# Altium schematic sheet LM399.SchDoc
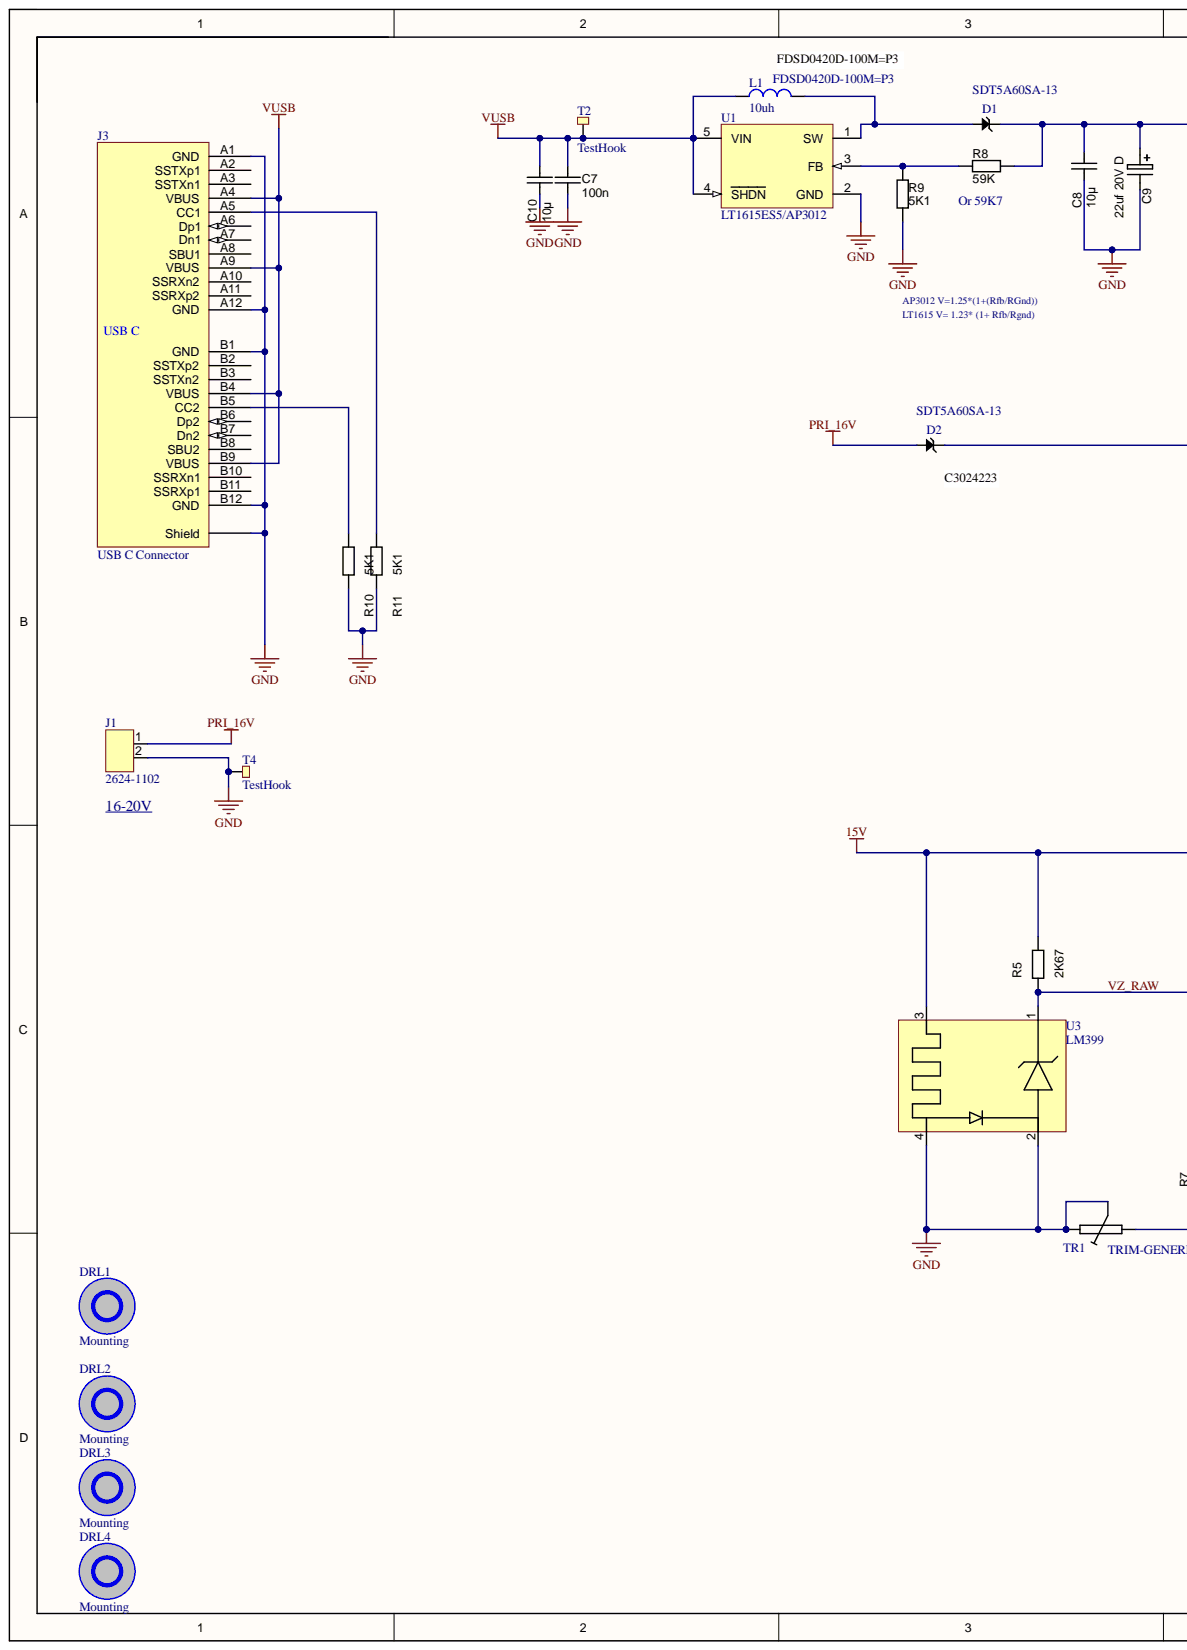
[diagram p0: LM399.SchDoc, left]
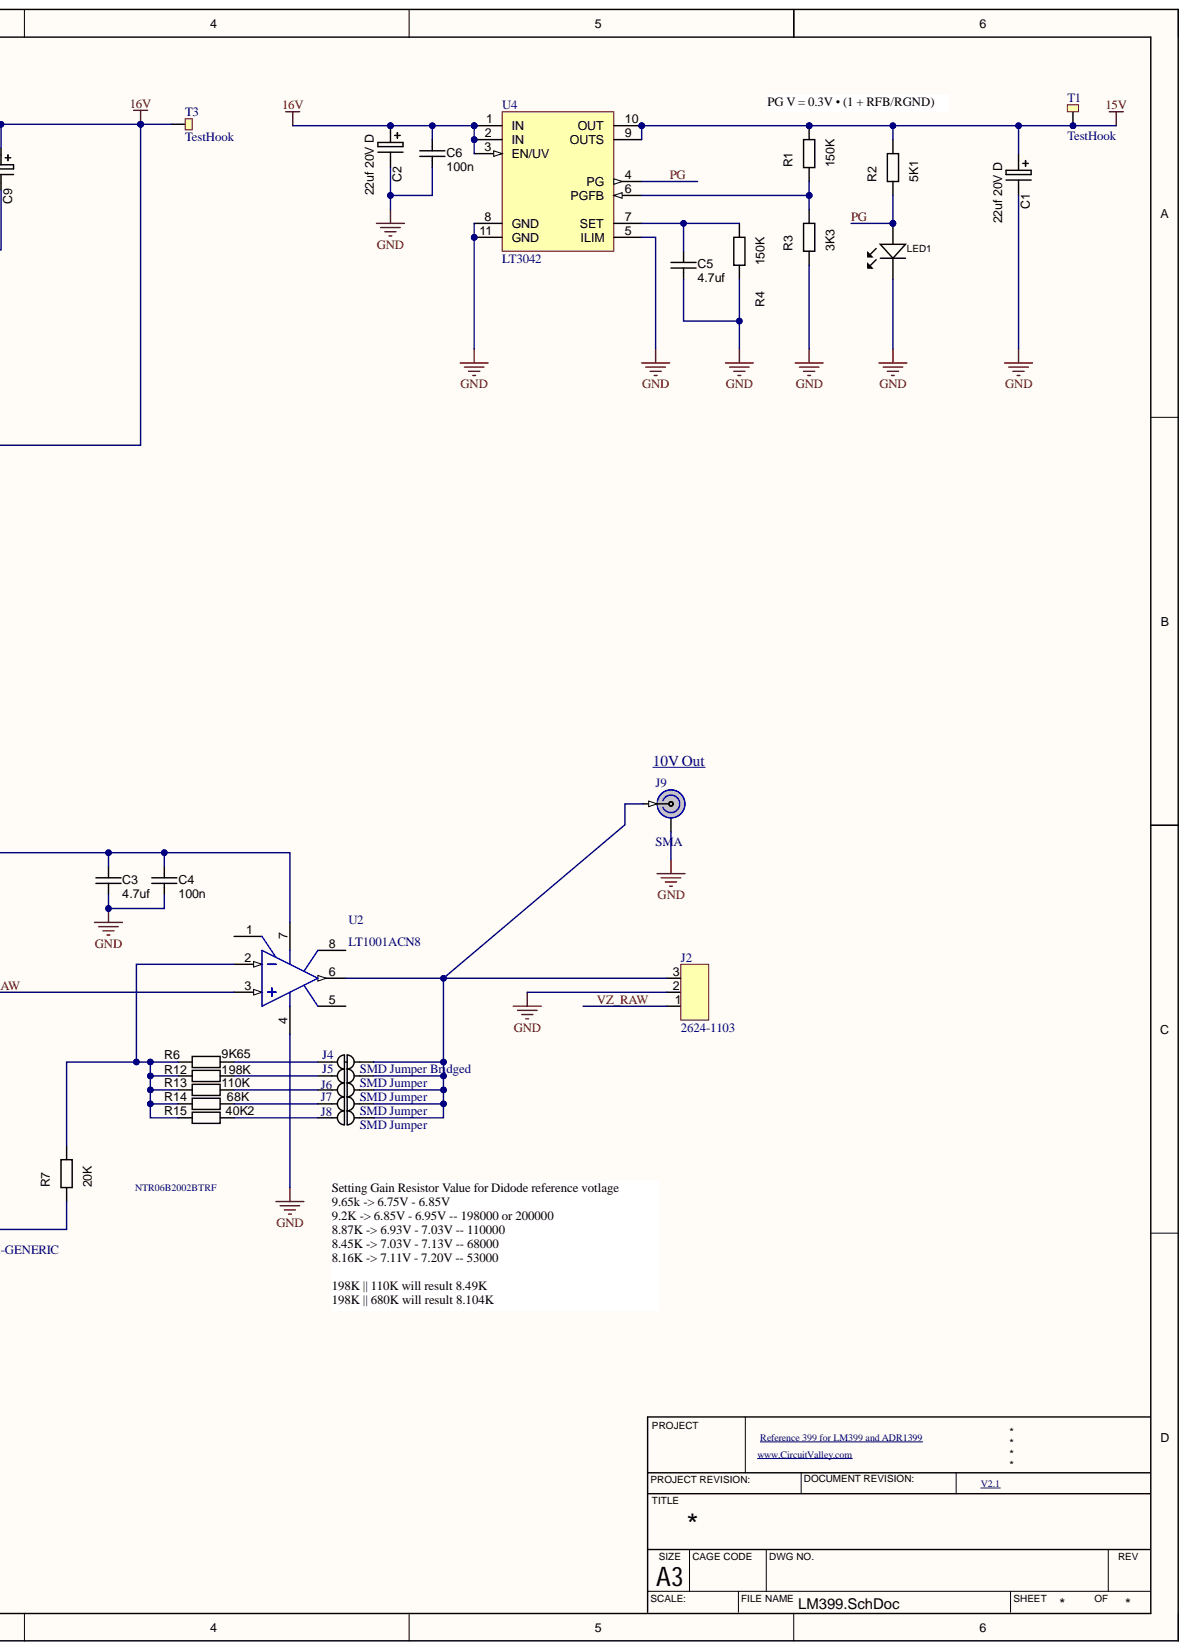
[diagram p1: LM399.SchDoc, right]

## Parts
C1  value 22uf 20V D  Manufacturer Size Code: D  [CAPMP7343X310N]  (hidden comment: 47uf 20V D)
C2  value 22uf 20V D  Manufacturer Size Code: D  [CAPMP7343X310N]  (hidden comment: 47uf 20V D)
C3  value 4.7uf  [CAPC1608N]  (hidden comment: approved sub OK)
C4  value 100n  [CAPC1608N]  (hidden comment: approved sub OK)
C5  value 4.7uf  [CAPC1608N]  (hidden comment: approved sub OK)
C6  value 100n  [CAPC1608N]  (hidden comment: approved sub OK)
C7  value 100n  [CAPC1608N]  (hidden comment: approved sub OK)
C8  10µ  [CAPC3225N]
C9  value 22uf 20V D  Manufacturer Size Code: D  [CAPMP7343X310N]  (hidden comment: 47uf 20V D)
C10  10µ  [CAPC3225N]
D1  SDT5A60SA-13  [DO-214AC]
D2  SDT5A60SA-13  [DO-214AC]
DRL1  Mounting  [NO_COPPER_NOMASK_2m75_6m2]
DRL2  Mounting  [NO_COPPER_NOMASK_2m75_6m2]
DRL3  Mounting  [NO_COPPER_NOMASK_2m75_6m2]
DRL4  Mounting  [NO_COPPER_NOMASK_2m75_6m2]
J1  2624-1102  [2624-1102]
J2  2624-1103  [2624-1103]
J3  USB C Connector  [UT11113-11602-7H]
J4  SMD Jumper Bridged  [SMD_Jumper_bridge]
J5  SMD Jumper  [SMD_Jumper]
J6  SMD Jumper  [SMD_Jumper]
J7  SMD Jumper  [SMD_Jumper]
J8  SMD Jumper  [SMD_Jumper]
J9  SMA  [SMA_Connector]
L1  10uh  value FDSD0420D-100M=P3  [FDSD0420-H-2R2M]
LED1  (no value)  [LED-0805-K]
R1  value 150K  [RESC1608N]  (hidden comment: RES_NL_0603)
R2  value 5K1  [RESC1608N]  (hidden comment: RES_NL_0603)
R3  value 3K3  [RESC1608N]  (hidden comment: RES_NL_0603)
R4  value 150K  [RESC1608N]  (hidden comment: RES_NL_0603)
R5  value 2K67  [RESC1608N]  (hidden comment: RES_NL_0603)
R6  value 9K65  [RESC1608N]  (hidden comment: RES_NL_0603)
R7  value 20K  [RESC1608N]  (hidden comment: RES_NL_0603)
R8  value 59K  [RESC1608N]  (hidden comment: RES_NL_0603)
R9  value 5K1  [RESC1608N]  (hidden comment: RES_NL_0603)
R10  value 5K1  [RESC1608N]  (hidden comment: RES_NL_0603)
R11  value 5K1  [RESC1608N]  (hidden comment: RES_NL_0603)
R12  value 198K  [RESC1608N]  (hidden comment: RES_NL_0603)
R13  value 110K  [RESC1608N]  (hidden comment: RES_NL_0603)
R14  value 68K  [RESC1608N]  (hidden comment: RES_NL_0603)
R15  value 40K2  [RESC1608N]  (hidden comment: RES_NL_0603)
T1  TestHook  [TEST_PAD_HOOK]
T2  TestHook  [TEST_PAD_HOOK]
T3  TestHook  [TEST_PAD_HOOK]
T4  TestHook  [TEST_PAD_HOOK]
TR1  TRIM-GENERIC  [TRIMMER-MT]
U1  LT1615ES5/AP3012  [SOT23-5]
U2  LT1001ACN8  [N8]
U3  LM399  [TO-46]
U4  LT3042  [MSOP10]

## Nets
15V: C1.1 C3.1 C4.1 R1.1 R2.1 R5.1 T1.1 U2.7 U3.3 U4.9(OUTS) U4.10(OUT)
16V: C2.1 C6.1 C8.1 C9.1 D1.2 D2.2 R8.2 T3.1 U4.1(IN) U4.2(IN) U4.3(EN/UV)
GND: C1.2 C2.2 C3.2 C4.2 C5.2 C6.2 C7.2 C8.2 C9.2 C10.2 J1.2 J2.2 J3.0(Shield) J3.A1(GND) J3.A12(GND) J3.B1(GND) J3.B12(GND) J9.2 LED1.K R3.2 R4.2 R9.1 R10.2 R11.2 T4.1 TR1.2 TR1.W U1.2(GND) U2.4 U3.2 U3.4 U4.5(ILIM) U4.8(GND) U4.11(GND)
PRI_16V: D2.1 J1.1
VUSB: C7.1 C10.1 J3.A4(VBUS) J3.A9(VBUS) J3.B4(VBUS) J3.B9(VBUS) L1.1 T2.1 U1.4(SHDN) U1.5(VIN)
PG: LED1.A R2.2 U4.4(PG)
VZ_RAW: J2.1 R5.2 U2.3 U3.1
NetC5_1: C5.1 R4.1 U4.7(SET)
NetD1_1: D1.1 L1.2 U1.1(SW)
NetJ2_3: J2.3 J4.1 J5.1 J6.1 J7.1 J8.1 J9.1 U2.6
NetJ3_A5: J3.A5(CC1) R11.1
NetJ3_B5: J3.B5(CC2) R10.1
NetJ4_2: J4.2 R6.2
NetJ5_2: J5.2 R12.2
NetJ6_2: J6.2 R13.2
NetJ7_2: J7.2 R14.2
NetJ8_2: J8.2 R15.2
NetR1_2: R1.2 R3.1 U4.6(PGFB)
NetR6_1: R6.1 R7.1 R12.1 R13.1 R14.1 R15.1 U2.2
NetR7_2: R7.2 TR1.1
NetR8_1: R8.1 R9.2 U1.3(FB)

Not connected: J3 A2(SSTXp1) A3(SSTXn1) A6(Dp1) A7(Dn1) A8(SBU1) A10(SSRXn2) A11(SSRXp2) B2(SSTXp2) B3(SSTXn2) B6(Dp2) B7(Dn2) B8(SBU2) B10(SSRXn1) B11(SSRXp1); U2 1 5 8

## Library data of the parts
C1 C2 C9 = CAPT_330u_2917_6V3: Capacitor SMD Tant 330uF 20% 6V3 2917; Manufacturer Part Number: T520D337M006ATE015; Part: TCJY226M025R0070; ESR (Equivalent Series Resistance): 15 mOhm; Material: Solid Tantalum; Ratings: 6.3V; Component Kind: Standard; ComponentLink1Description: T520D Datasheet; ComponentLink1URL: http://www.kemet.com/datasheets&T520D337M006ATE015; Height - Seated (Max): 0.122" (3.10mm); Library Name: PBL - Capacitors.SCHLIB; Operating Temperature: -55°C ~ 125°C; Package / Case: 2917 (7343 Metric); Size / Dimension: 0.287" L x 0.169" W (7.30mm x 4.30mm); Supplier 1: Digi-Key; Supplier Part Number 1: 399-4052-1-ND; Tolerance: ±20%
C3-C7 = CAPC_1n_0603_100V: Capacitor SMD Cer 1nF 10% 100V X7R 0603; Manufacturer 1: Murata Electronics North America; Manufacturer Part Number 1: GCM188R72A102KA37D; Material: ceramic; Ratings: 100V; X7R; Component Kind: Standard; ComponentLink1Description: http://search.murata.co.jp/Ceramy/image/img/PDF/ENG/GCM188R72A102KA37.pdf; ComponentLink1URL: http://search.murata.co.jp/Ceramy/image/img/PDF/ENG/GCM188R72A102KA37.pdf; Library Name: PBL - Capacitors.SCHLIB; Operating Temperature: -55°C ~ 125°C; Package / Case: 0603 (1608 metric); Size / Dimension: 0.063" L x 0.031" W (1.60mm x 0.80mm); Supplier 1: Digi-Key; Supplier Part Number 1: 490-4780-1-ND; Thickness (Max): 0.035" (0.90mm); Tolerance: ±10%
C8 C10 = CAPC_10u_1210_50V: Capacitor SMD Cer 10uF 20% 16V X5R 1210; Manufacturer 1: Taiyo Yuden; Manufacturer Part Number 1: UMK325BJ106MM-T; Material: ceramic; Ratings: 50V; X5R; Component Kind: Standard; Library Name: PBL - Capacitors.SCHLIB; Package / Case: 1210 (3225 Metric); Size / Dimension: 0.126" L x 0.098" W (3.20mm x 2.50mm); Supplier 1: Digi-Key; Supplier Part Number 1: 587-2225-1-ND; Tolerance: ±20%; Value: 10µ
D1 D2 = Schottky_diode: Component Kind: Standard; Library Name: cvlib.SchLib
DRL1-DRL4 = Mountinghole: Mounting Hole; Component Kind: Standard; Library Name: cvlib.SchLib
J3 = USB_C: Component Kind: Standard; Description: USB C connector; Library Name: cvlib.SchLib
J4 = SMD_Jumper_Bridged: SMD Jumper; Component Kind: Net Tie; Library Name: cvlib.SchLib
J5-J8 = SMD_Jumper: Component Kind: Net Tie; Description: SMD Jumper; Library Name: cvlib.SchLib
J9 = SMA Connector: Component Kind: Standard; Library Name: cvlib.SchLib
L1 = inductor_smd: Component Kind: Standard; Library Name: cvlib.SchLib
LED1 = LED_SMD_Green_0805: LED, 0805 SMD, Green; Manufacturer 1: Ligitek; Manufacturer Part Number 1: LG170G-CT; Material: GaP; Ratings: 10 mcd; 573 nm; Component Kind: Standard; Library Name: PBL - Light Sources.SCHLIB; Package / Case: 0805
R1-R9 R11-R15 = RES_NL_0603: Resistor, SMD chip; Manufacturer 1: Royal Ohm; Ratings: 1/10 W; Tolerance: ±5%; Component Kind: Standard; Library Name: PBL - Resistors.SCHLIB; Package / Case: 0603 (1608 metric)
R10 = RES_NL_0603: Resistor, SMD chip; Manufacturer 1: Royal Ohm; Ratings: 1/10 W; Tolerance: ±5%; Value: 5K1; Component Kind: Standard; Library Name: PBL - Resistors.SCHLIB; Package / Case: 0603 (1608 metric)
TR1 = THTRIM-GENERIC: TRIMMABLE RESISTOR; Component Kind: Standard; Library Name: Generic.intLib
U1 = AP3012: Boost Conveter; Component Kind: Standard; Library Name: cvlib.SchLib
U2 = LT1001ACN8: General Purpose, High Precision Op Amp; Note: Pin 5 is NC (not internally connected) pin.; Component Kind: Standard; ComponentLink1Description: Manufacturer Link; ComponentLink1URL: http://www.linear.com/; ComponentLink2Description: Datasheet; ComponentLink2URL: http://www.linear.com/pc/downloadDocument.do?navId=H0,C1,C1154,C1009,C1021,P1206,D1672; DatasheetVersion: 1990; LatestRevisionDate: 29-May-2009; LatestRevisionNote: Component Body Added.; Library Name: LT Operational Amplifier.IntLib; PackageDescription: DIP, Plastic, 8-Pin, Row Spacing 7.62 mm, Pitch 2.54 mm; PackageReference: N8; PackageVersion: N8 1002; PCB3D: N8; Published: 8-Jun-2000; Simulation: LT1001A; Publisher: Altium Limited
U4 = LT3042: Low noise Linear Regulator; Component Kind: Standard; Library Name: cvlib.SchLib
J1 = 2624-1102: Component Kind: Standard; Description: 2624-1102; Library Name: cvlib.SchLib
J2 = 2624-1103: Component Kind: Standard; Description: 2624-1103; Library Name: cvlib.SchLib
T1-T4 = TestHook: Component Kind: Standard; Library Name: cvlib.SchLib
U3 = LM399: Component Kind: Standard; Part: LM399; Library Name: cvlib.SchLib
